# Altium schematic sheet Raspberry Pi2+ Expansion Slot.SchDoc
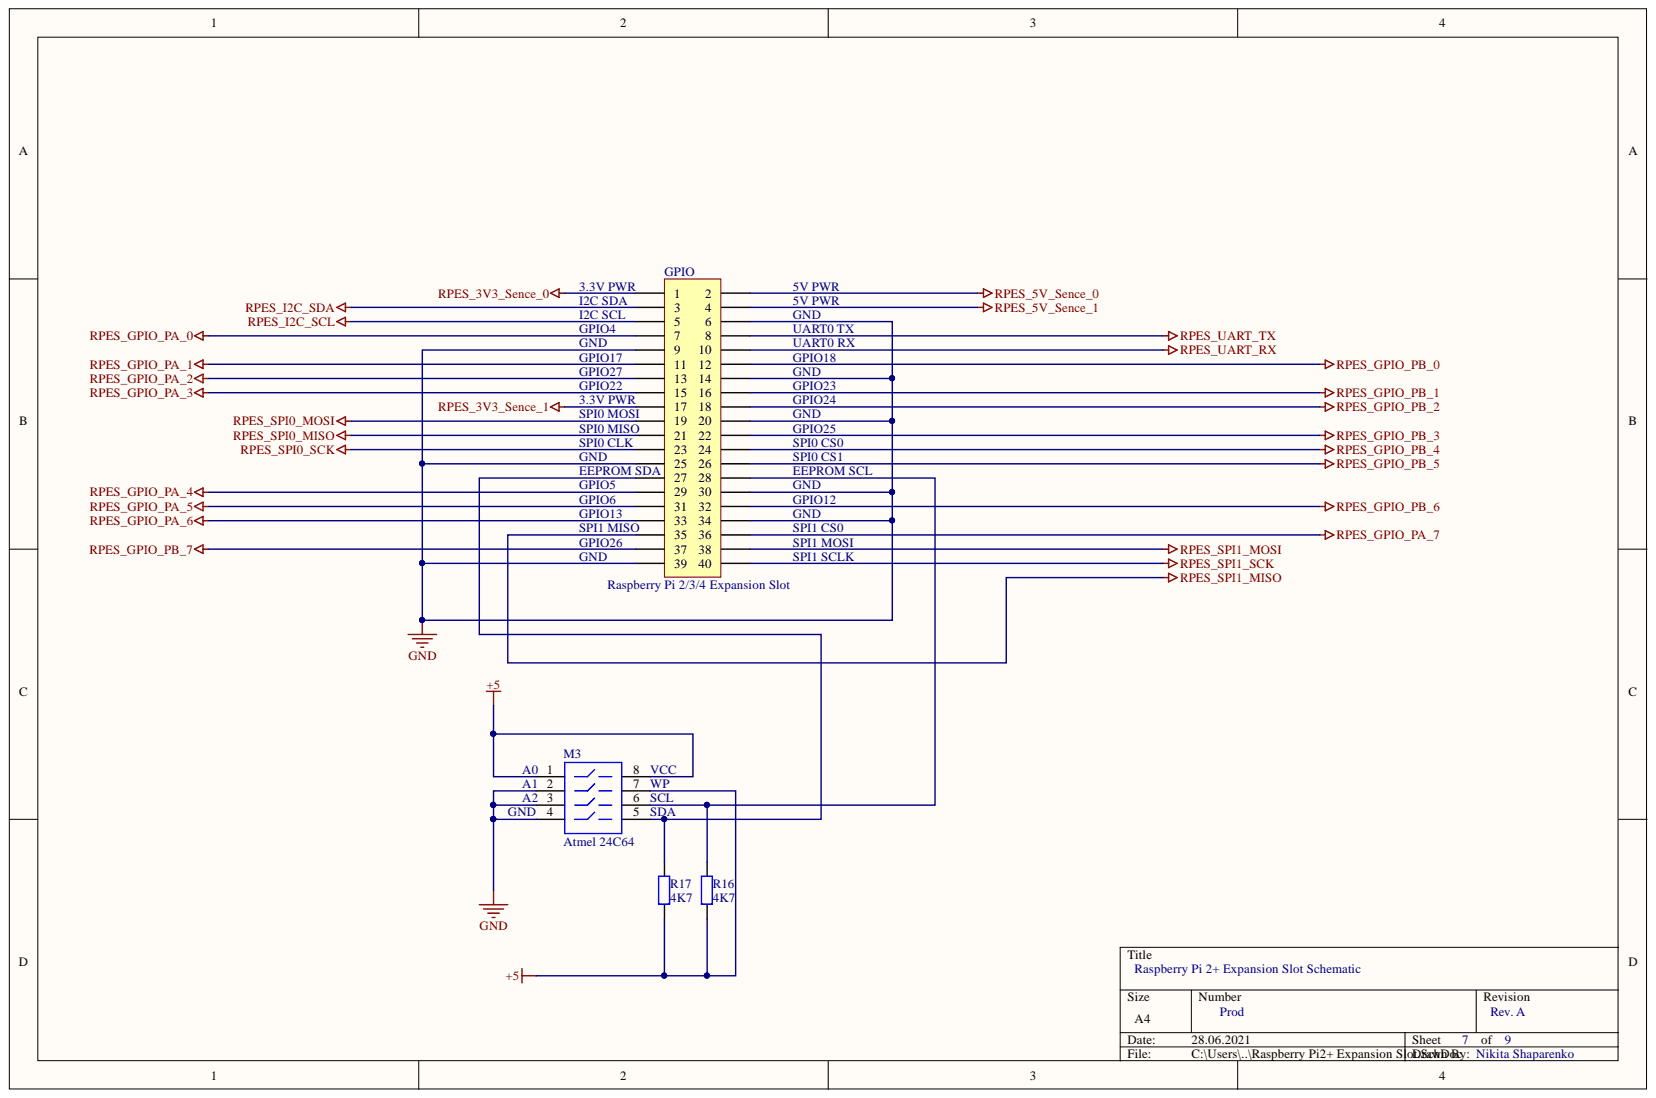
[diagram p0: Raspberry Pi2+ Expansion Slot.SchDoc]

## Parts
GPIO  Raspberry Pi 2/3/4 Expansion Slot  [HDR2X20]
M3  Atmel 24C64  [DIP-8]
R16  value 4K7  [AXIAL-0.4]  (hidden comment: Res2)
R17  value 4K7  [AXIAL-0.4]  (hidden comment: Res2)

## Nets
+5: M3.1 M3.7 M3.8 R16.1 R17.1
GND: GPIO.6 GPIO.9 GPIO.14 GPIO.20 GPIO.25 GPIO.30 GPIO.34 GPIO.39 M3.2 M3.3 M3.4
RPES_3V3_Sence_0: GPIO.1
RPES_3V3_Sence_1: GPIO.17
RPES_5V_Sence_0: GPIO.2
RPES_5V_Sence_1: GPIO.4
RPES_GPIO_PA_0: GPIO.7
RPES_GPIO_PA_1: GPIO.11
RPES_GPIO_PA_2: GPIO.13
RPES_GPIO_PA_3: GPIO.15
RPES_GPIO_PA_4: GPIO.29
RPES_GPIO_PA_5: GPIO.31
RPES_GPIO_PA_6: GPIO.33
RPES_GPIO_PA_7: GPIO.36
RPES_GPIO_PB_0: GPIO.12
RPES_GPIO_PB_1: GPIO.16
RPES_GPIO_PB_2: GPIO.18
RPES_GPIO_PB_3: GPIO.22
RPES_GPIO_PB_4: GPIO.24
RPES_GPIO_PB_5: GPIO.26
RPES_GPIO_PB_6: GPIO.32
RPES_GPIO_PB_7: GPIO.37
RPES_I2C_SCL: GPIO.5
RPES_I2C_SDA: GPIO.3
RPES_SPI0_MISO: GPIO.21
RPES_SPI0_MOSI: GPIO.19
RPES_SPI0_SCK: GPIO.23
RPES_SPI1_MISO: GPIO.35
RPES_SPI1_MOSI: GPIO.38
RPES_SPI1_SCK: GPIO.40
RPES_UART_RX: GPIO.10
RPES_UART_TX: GPIO.8
NetGPIO_27: GPIO.27 M3.5 R17.2
NetGPIO_28: GPIO.28 M3.6 R16.2

## Library data of the parts
GPIO = Header 20X2: Header, 20-Pin, Dual row; Component Kind: Standard; LatestRevisionDate: 17-Jul-2002; LatestRevisionNote: Re-released for DXP Platform.; Library Name: Miscellaneous Connectors.IntLib; Signal Integrity: Connector; Publisher: Altium Limited
M3 = SW-DIP4: DIP Switch; Component Kind: Standard; LatestRevisionDate: 17-Jul-2002; LatestRevisionNote: Re-released for DXP Platform.; Library Name: Miscellaneous Devices.IntLib; PackageReference: DIP-8; Published: 24-Mar-1999; Simulation: dpsw4; Publisher: Altium Limited
R16 R17 = Res2: Resistor; Component Kind: Standard; LatestRevisionDate: 17-Jul-2002; LatestRevisionNote: Re-released for DXP Platform.; Library Name: Miscellaneous Devices.IntLib; PackageDescription: Axial Device, Thru-Hole; 2 Leads; 0.4 in Pin Spacing; PackageReference: AXIAL-0.4; Published: 8-Jun-2000; Signal Integrity: Res; Simulation: RESISTOR; Publisher: Altium Limited
